# Altium schematic sheet Full Schematic.SchDoc
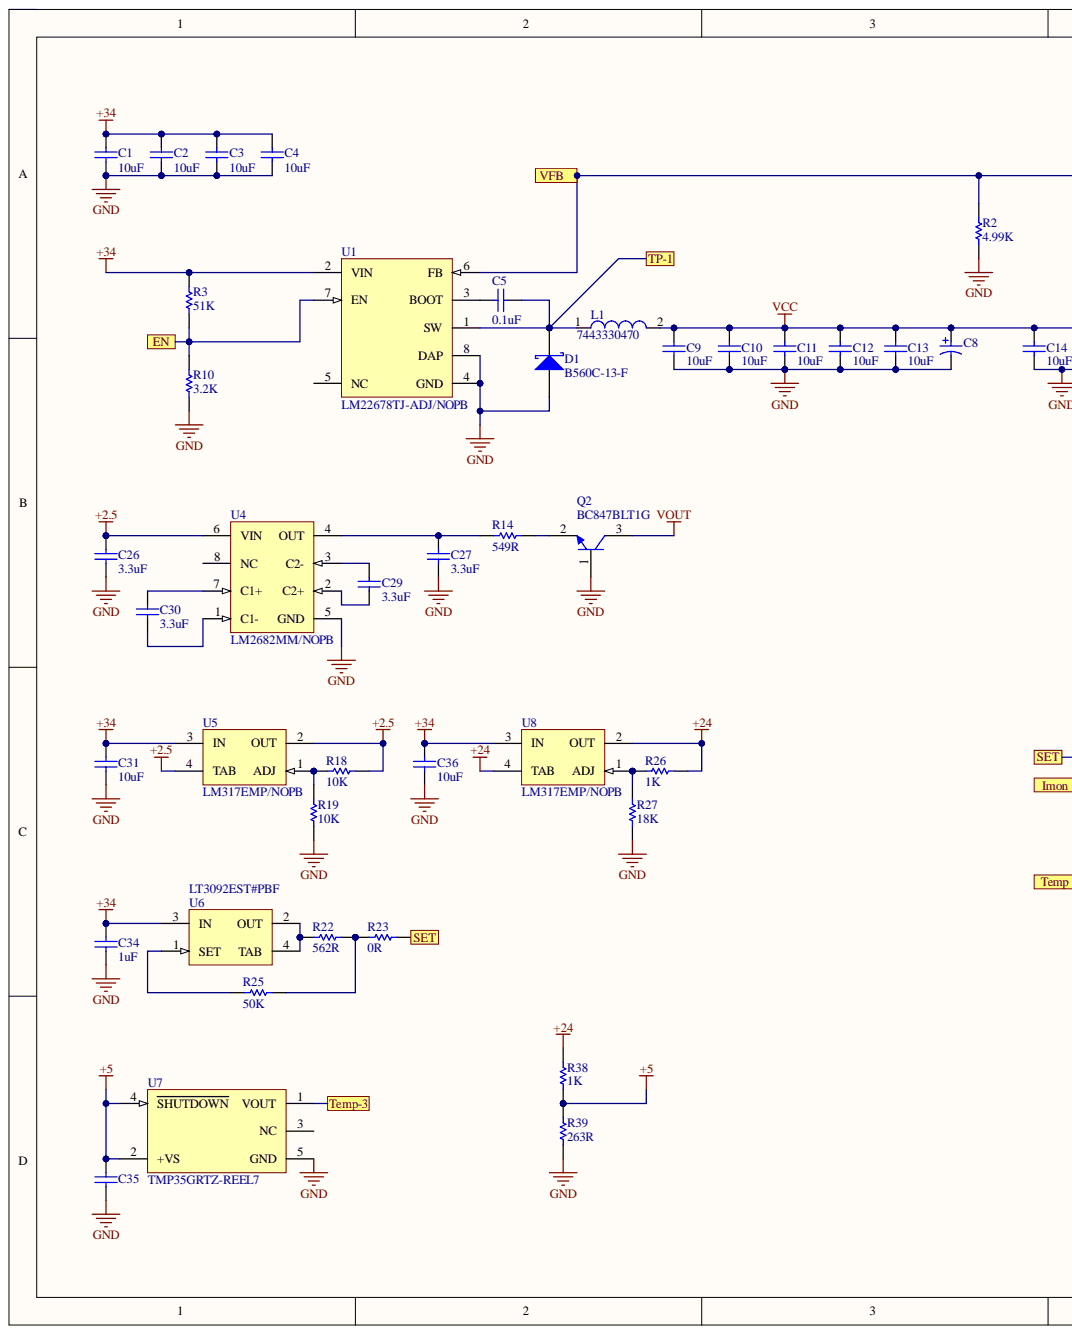
[diagram p0: Full Schematic.SchDoc, left]
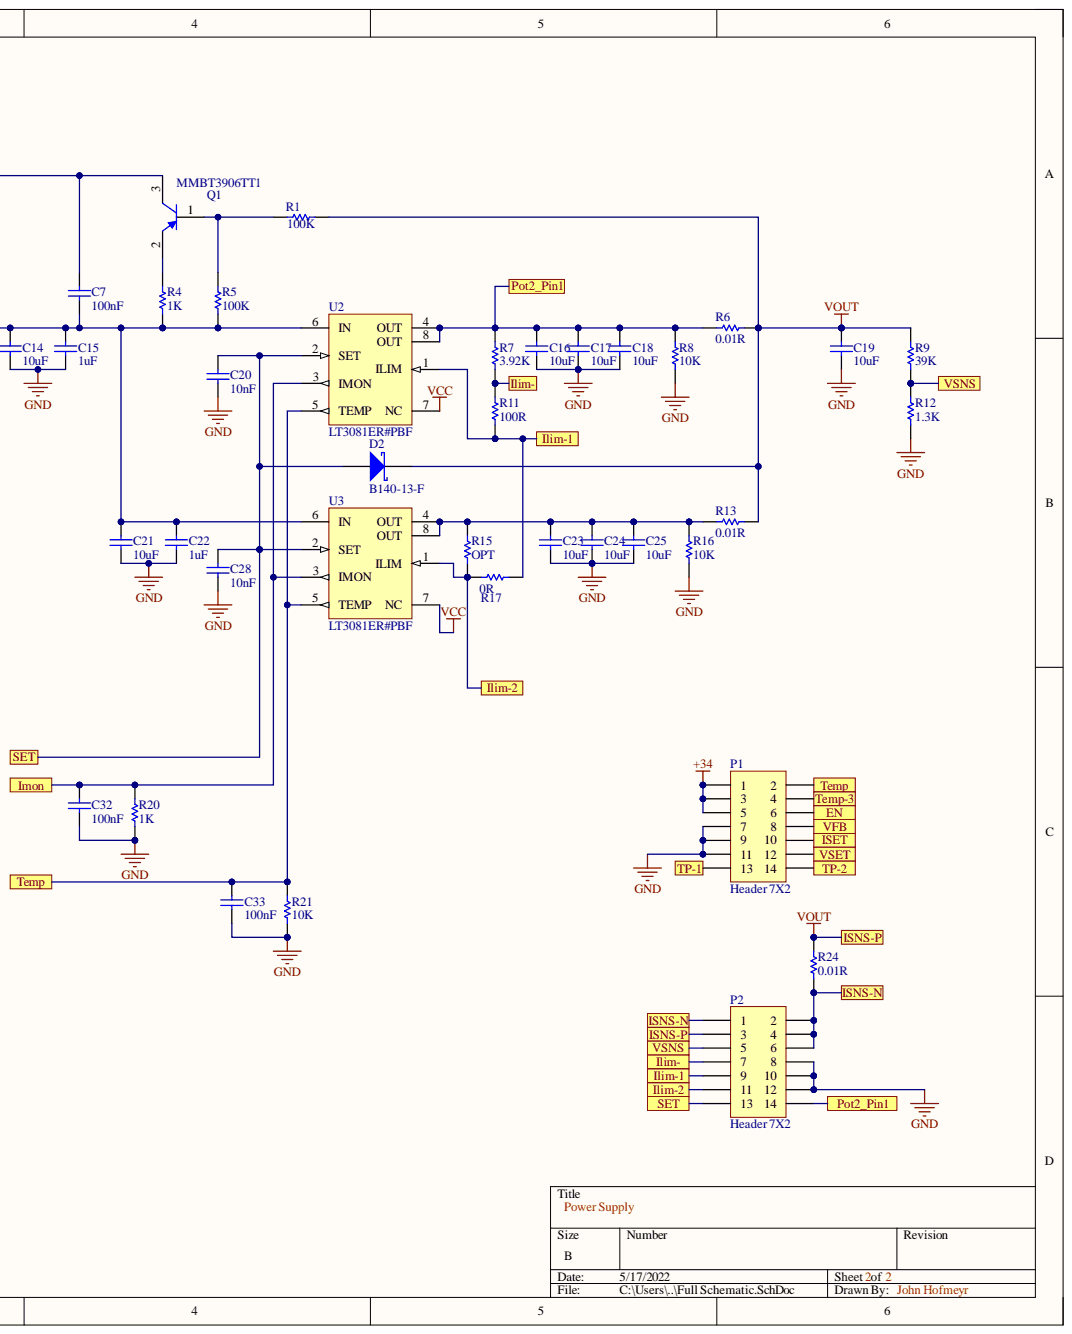
[diagram p1: Full Schematic.SchDoc, right]

## Parts
C1  10uF  [CAPC1210(3225)170_L]
C2  10uF  [CAPC1210(3225)170_L]
C3  10uF  [CAPC1210(3225)170_L]
C4  10uF  [CAPC1210(3225)170_L]
C5  0.1uF  [MURA-0805_H_1.35_M]
C7  100nF  [MURA-0805_H_1.35_M]
C8  UWT1H101MNL1GS  [NIC-UWT1H101MNL1GS_L]  (value not drawn)
C9  10uF  [CAPC1210(3225)170_L]
C10  10uF  [CAPC1210(3225)170_L]
C11  10uF  [CAPC1210(3225)170_L]
C12  10uF  [CAPC1210(3225)170_L]
C13  10uF  [CAPC1210(3225)170_L]
C14  10uF  [CAPC1210(3225)170_L]
C15  1uF  [MURA-0805_H_1.35_M]
C16  10uF  [CAPC1210(3225)170_L]
C17  10uF  [CAPC1210(3225)170_L]
C18  10uF  [CAPC1210(3225)170_L]
C19  10uF  [CAPC1210(3225)170_L]
C20  10nF  [MURA-0805_H_1.35_M]
C21  10uF  [CAPC1210(3225)170_L]
C22  1uF  [MURA-0805_H_1.35_M]
C23  10uF  [CAPC1210(3225)170_L]
C24  10uF  [CAPC1210(3225)170_L]
C25  10uF  [CAPC1210(3225)170_L]
C26  3.3uF  [MURA-0805_H_1.35_M]
C27  3.3uF  [MURA-0805_H_1.35_M]
C28  10nF  [MURA-0805_H_1.35_M]
C29  3.3uF  [MURA-0805_H_1.35_M]
C30  3.3uF  [MURA-0805_H_1.35_M]
C31  10uF  [CAPC1210(3225)170_L]
C32  100nF  [MURA-0805_H_1.35_M]
C33  100nF  [MURA-0805_H_1.35_M]
C34  1uF  [MURA-0805_H_1.35_M]
C35  (no value)  [CAPC0805(2012)130_N]
C36  10uF  [CAPC1210(3225)170_L]
D1  B560C-13-F  [DIOD-B5X0C-2_M]
D2  B140-13-F  [DIOD-B1X0-2_V]
L1  7443330470  [WE-HCC-1090]
P1  Header 7X2  [HDR2X7]
P2  Header 7X2  [HDR2X7]
Q1  MMBT3906TT1  [ONSC-SC-75-3-463-01_V]
Q2  BC847BLT1G  [ONSC-SOT-23-3-318-08_V]
R1  100K  [RESC2013X60X40LL20T25]
R2  4.99K  [RESC2013X60X40LL20T25]
R3  51K  [RESC2013X60X40LL20T25]
R4  1K  [RESC2013X60X40LL20T25]
R5  100K  [RESC2013X60X40LL20T25]
R6  0.01R  [RESC1206(3216)_M]
R7  3.92K  [RESC2013X60X40LL20T25]
R8  10K  [RESC2013X60X40LL20T25]
R9  39K  [RESC2013X60X40LL20T25]
R10  3.2K  [RESC2013X60X40LL20T25]
R11  100R  [RESC2013X60X40LL20T25]
R12  1.3K  [RESC2013X60X40LL20T25]
R13  0.01R  [RESC1206(3216)_M]
R14  549R  [RESC2013X60X40LL20T25]
R15  OPT  [RESC2013X60X40LL20T25]
R16  10K  [RESC2013X60X40LL20T25]
R17  0R  [RESC2013X60X40LL20T25]
R18  10K  [RESC2013X60X40LL20T25]
R19  10K  [RESC2013X60X40LL20T25]
R20  1K  [RESC2013X60X40LL20T25]
R21  10K  [RESC2013X60X40LL20T25]
R22  562R  [RESC2013X60X40LL20T25]
R23  0R  [RESC2013X60X40LL20T25]
R24  0.01R  [RESC1206(3216)_M]
R25  50K  [RESC2013X60X40LL20T25]
R26  1K  [RESC2013X60X40LL20T25]
R27  18K  [RESC2013X60X40LL20T25]
R38  1K  [RESC2013X60X40LL20T25]
R39  263R  [RESC2013X60X40LL20T25]
U1  LM22678TJ-ADJ/NOPB  [NDR0007A_M]
U2  LT3081ER#PBF  [LT-R-7_L]
U3  LT3081ER#PBF  [LT-R-7_L]
U4  LM2682MM/NOPB  [DGK0008A_L]
U5  LM317EMP/NOPB  [DCY0004A_L]
U6  LT3092EST#PBF  [LT-ST-3-SOT-223_M]
U7  TMP35GRTZ-REEL7  [ADI-RJ-5_N]
U8  LM317EMP/NOPB  [DCY0004A_L]

## Ports
EN, Ilim-, Ilim-1, Ilim-2, Imon, ISET, ISNS-N, ISNS-P, Pot2_Pin1, SET, Temp, Temp-3, TP-1, TP-2, VFB, VSET, VSNS

## Nets
+2.5: C26.2 R18.2 U4.6(VIN) U5.2(OUT) U5.4(TAB)
+5: C35.2 R38.2 R39.1 U7.2(+VS) U7.4(SHUTDOWN)
+24: R26.2 R38.1 U8.2(OUT) U8.4(TAB)
+34: C1.2 C2.2 C3.2 C4.2 C31.2 C34.2 C36.2 P1.1 P1.3 P1.5 R3.2 U1.2(VIN) U5.3(IN) U6.3(IN) U8.3(IN)
GND: C1.1 C2.1 C3.1 C4.1 C8.2 C9.1 C10.1 C11.1 C12.1 C13.1 C14.1 C15.1 C16.1 C17.1 C18.1 C19.1 C20.1 C21.1 C22.1 C23.1 C24.1 C25.1 C26.1 C27.1 C28.1 C31.1 C32.1 C33.1 C34.1 C35.1 C36.1 D1.2 P1.7 P1.9 P1.11 P2.8 P2.10 P2.12 Q2.1 R2.2 R8.2 R10.1 R12.2 R16.2 R19.2 R20.2 R21.2 R27.2 R39.2 U1.4(GND) U1.8(DAP) U4.5(GND) U7.5(GND)
VCC: C7.1 C8.1 C9.2 C10.2 C11.2 C12.2 C13.2 C14.2 C15.2 C21.2 C22.2 L1.2 R4.2 R5.2 U2.6(IN) U2.7(NC) U3.6(IN) U3.7(NC)
EN: P1.6 R3.1 R10.2 U1.7(EN) ; port EN
Ilim-: P2.7 R7.2 R11.1 ; port Ilim-
Ilim-1: P2.9 R11.2 R17.2 U2.1(ILIM) ; port Ilim-1
Ilim-2: P2.11 R15.1 R17.1 U3.1(ILIM) ; port Ilim-2
Imon: C32.2 R20.1 U2.3(IMON) U3.3(IMON) ; port Imon
ISET: P1.10 ; port ISET
ISNS-N: P2.1 P2.2 P2.4 P2.6 R24.1 ; port ISNS-N
Pot2_Pin1: C16.2 C17.2 C18.2 P2.14 R6.1 R7.1 R8.1 U2.4(OUT) U2.8(OUT) ; port Pot2_Pin1
SET: C20.2 C28.2 D2.2 P2.13 R23.2 U2.2(SET) U3.2(SET) ; port SET
Temp: C33.2 P1.2 R21.1 U2.5(TEMP) U3.5(TEMP) ; port Temp
Temp-3: P1.4 U7.1(VOUT) ; port Temp-3
TP-1: C5.1 D1.1 L1.1 P1.13 U1.1(SW) ; port TP-1
TP-2: P1.14 ; port TP-2
VFB: C7.2 P1.8 Q1.3 R2.1 U1.6(FB) ; port VFB
VOUT: C19.2 D2.1 P2.3 Q2.3 R1.1 R6.2 R9.1 R13.2 R24.2 ; port ISNS-P
VSET: P1.12 ; port VSET
VSNS: P2.5 R9.2 R12.1 ; port VSNS
NetC5_2: C5.2 U1.3(BOOT)
NetC23_2: C23.2 C24.2 C25.2 R13.1 R15.2 R16.1 U3.4(OUT) U3.8(OUT)
NetC27_2: C27.2 R14.1 U4.4(OUT)
NetC29_1: C29.1 U4.2(C2+)
NetC29_2: C29.2 U4.3(C2-)
NetC30_1: C30.1 U4.1(C1-)
NetC30_2: C30.2 U4.7(C1+)
NetQ1_1: Q1.1 R1.2 R5.1
NetQ1_2: Q1.2 R4.1
NetQ2_2: Q2.2 R14.2
NetR18_1: R18.1 R19.1 U5.1(ADJ)
NetR22_1: R22.1 R23.1 R25.1
NetR22_2: R22.2 U6.2(OUT) U6.4(TAB)
NetR25_2: R25.2 U6.1(SET)
NetR26_1: R26.1 R27.1 U8.1(ADJ)

Not connected: U1 5(NC); U4 8(NC); U7 3(NC)

## Library data of the parts
C1-C4 C9-C14 C16-C19 C21 C23-C25 C31 C36 = CMP-1038-00364-1: CAP 390pF 50V ±5% 1210 (3225 Metric) Thickness 1.7mm SMD; Case-EIA: 1210; Case-Metric: 3225; Tolerance: Â±5%; Component Kind: Standard; Library Name: Altium Content Vault; Max Thickness: 1.7 mm; Rated Voltage: 50 V; Technology: SMT; Value: 390pF
C5 C7 C15 C20 C22 C26-C30 C32-C34 = CMP-2000-05254-1: CAP, Ceramic, 10 uF, 10%, 10 V, 0805, RoHS, Tape and Reel; Manufacturer: Murata; Capacitance: 10µF; Catalog Drawings: GRMSeries_0805_1.25; Featured Product: GA3SafetyRecognizedCapsandHi-CapMLCC; Online Catalog: GRMSeries; Other Names: 490-1709-6; Product Training Modules: High-CapMultilayerCeramicCapacitors; Temperature Coefficient: X5R; Voltage - Rated: 10V; Applications: GeneralPurpose; Category: Capacitors; Component Kind: Standard; Datasheet URL: https://datasheet.ciiva.com/3777/210grm21br61a106ke1923-3777175.pdf; Datasheet Version: Aug-14; Family: CeramicCapacitors; Features: -; Height - Seated (Max): -; Lead Spacing: -; Lead Style: -; Library Name: Altium Content Vault; Manufacturer URL: http://www.murata.com/; Mounting Type: SurfaceMount,MLCC; Operating Temperature: -55degC~85degC; Package / Case: 0805(2012Metric); Package Description: 2-Pin Surface Mount Device (0805), Body 2.1 x 1.35 mm; Package Reference: 805; Packaging: Digi-Reel; Ratings: -; Series: GRM; Size / Dimension: 0.079"Lx0.049"W(2.00mmx1.25mm); Standard Package: 1; Thickness (Max): 0.053"(1.35mm); Tolerance: ±10%
C8 = CMP-2000-07373-1: Aluminum Electrolytic Capacitor, 100 uF, +/- 20%, 50 V, -55 to 105 degC, 2-Pin SMD, RoHS, Tape and Reel; Manufacturer: Nichicon; Capacitance: 100µF; Rated Voltage: 50V; Type: Can; Case Size/Dimension: Ø8x10mm; Component Kind: Standard; Datasheet URL: http://products.nichicon.co.jp/en/pdf/XJA043/e-uwt.pdf; Datasheet Version: 08/2015; Library Name: Altium Content Vault; Manufacturer URL: http://www.nichicon.co.jp/english/; Mounting Technology: SurfaceMount; Operating Temp-Max: 105°C; Package Description: Aluminum Electrolytic Capacitor, Body 8.3 x 8.3 mm, Height 10.8 mm; Package Reference: UWT1H101MNL1GS
C35 = CMP-1036-04061-1: CAP 22nF 50V ±5% 0805 (2012 Metric) Thickness 1.2mm SMD; Case-EIA: 0805; Case-Metric: 2012; Tolerance: Â±5%; Component Kind: Standard; Library Name: Altium Content Vault; Max Thickness: 1.2 mm; Rated Voltage: 50 V; Technology: SMT; Value: 22nF
D1 = CMP-2000-05098-2: Schottky Barrier Rectifier, 5 A, 60 V, -55 to 125 degC, 2-Pin SMD, RoHS, Tape and Reel; Brand: DiodesIncorporated; Configuration: Single; If - Forward Current: 5A; Ifsm - Forward Surge Current: 100A; Ir - Reverse Current: 500uA; Unit Weight: 0.007408oz; Vf - Forward Voltage: 0.7V; Vrrm - Repetitive Reverse Voltage: 60V; Component Kind: Standard; Datasheet URL: https://datasheet.ciiva.com/27052/1538961-27052355.pdf; Datasheet Version: Rev. 7-2, 06/2007; Factory Pack Quantity: 3000; Height: 2.5mm; Length: 7.11mm; Library Name: Altium Content Vault; Manufacturer: DiodesIncorporated; Manufacturer URL: http://www.diodes.com/; Maximum Operating Temperature: +125C; Minimum Operating Temperature: -55C; Mounting Style: SMD/SMT; Operating Temperature Range: -55Cto+125C; Package / Case: SMC; Packaging: Reel; Product: SchottkyDiodes; Product Category: SchottkyDiodes&Rectifiers; RoHS: True; Series: B560; Technology: Si; Termination Style: SMD/SMT; Type: SchottkyBarrierRectifier; Width: 6.22mm
D2 = CMP-2000-05096-1: Surface Mount Schottky Barrier Rectifier, 1 A, -65 to 150 degC, 2-Pin SMA, RoHS, Tape and Reel; Brand: DiodesIncorporated; Configuration: Single; If - Forward Current: 1A; Ifsm - Forward Surge Current: 30A; Ir - Reverse Current: 500uA; Unit Weight: 0.002258oz; Vf - Forward Voltage: 0.5V; Vrrm - Repetitive Reverse Voltage: 40V; Component Kind: Standard; Datasheet URL: http://www.diodes.com/_files/datasheets/ds13002.pdf; Datasheet Version: Rev. 18-2, 05/2013; Factory Pack Quantity: 5000; Height: 2.1mm; Length: 4.6mm; Library Name: Altium Content Vault; Manufacturer: DiodesIncorporated; Manufacturer URL: http://www.diodes.com/; Maximum Operating Temperature: +150C; Minimum Operating Temperature: -65C; Mounting Style: SMD/SMT; Operating Temperature Range: -65Cto+150C; Package / Case: SMA; Package Description: 2-Pin Surface Mount Device, Body 4.3 x 2.605 mm; Package Reference: SMA; Packaging: Reel; Product: SchottkyDiodes; Product Category: SchottkyDiodes&Rectifiers; RoHS: True; Series: B140; Technology: Si; Termination Style: SMD/SMT; Type: SchottkyBarrierRectifier; Width: 2.92mm
L1 = CMP-0226-00118-1: SMD High Current Cube Inductor WE-HCC, L=4.70 µH; Inductance: 4.70 ÂµH; Component Kind: Standard; ComponentLink1Description: Manufacturer Link; ComponentLink1URL: http://www.we-online.com; ComponentLink2Description: Datasheet; ComponentLink2URL: http://www.we-online.de/katalog/media/pdf/7443330470.pdf; DatasheetVersion: ver 2, Nov-2009; Library Name: Altium Content Vault; PackageDescription: Inductor, 2-Leads, Body 10.9 x 10.0 mm; PackageReference: WE-HCC-1090; PackageVersion: 2009
P1 P2 = Header 7X2: Header, 7-Pin, Dual row; Component Kind: Standard; LatestRevisionDate: 17-Jul-2002; LatestRevisionNote: Re-released for DXP Platform.; Library Name: Miscellaneous Connectors.IntLib; Signal Integrity: Connector; Publisher: Altium Limited
Q1 = CMP-1048-00414-1: General Purpose Transistor, PNP Silicon, 3-Pin SC-75, Tape and Reel; Manufacturer: On Semiconductor; fT Min (MHz): 250; IC Continuous (A): -0.2; Note1: 0; Component Kind: Standard; ComponentLink1Description: Manufacturer URL; ComponentLink1URL: http://www.onsemi.com/; ComponentLink2Description: Datasheet; ComponentLink2URL: http://www.onsemi.cn/pub_link/Collateral/MMBT3906TT1-D.PDF; ComponentLink3Description: Package Specification; ComponentLink3URL: http://www.onsemi.com/pub_link/Collateral/463-01.PDF; DatasheetVersion: Rev. 1; Library Name: Altium Content Vault; Mounting Technology: Surface Mount; PackageDescription: 3-Pin Small Outline Transistor, Body 1.6 x 0.8 mm, Pitch 0.5 mm; PackageReference: SC-75-3-463-01; PackageVersion: Rev. F, 07/2005; Packing: Tape and Reel; PartNumber: MMBT3906TT1; Polarity: PNP; RoHS: FALSE
Q2 = CMP-1048-00759-1: General Purpose Transistor, NPN Silicon, 3-Pin SOT-23, Pb-Free, Tape and Reel; Manufacturer: On Semiconductor; fT Min (MHz): 100; IC Continuous (A): 0.1; Component Kind: Standard; ComponentLink1Description: Manufacturer URL; ComponentLink1URL: http://www.onsemi.com/; ComponentLink2Description: Datasheet; ComponentLink2URL: http://www.onsemi.cn/pub_link/Collateral/BC846ALT1-D.PDF; ComponentLink3Description: Package Specification; ComponentLink3URL: http://www.onsemi.cn/pub_link/Collateral/318-08.PDF; DatasheetVersion: Rev. 11; Library Name: Altium Content Vault; Mounting Technology: Surface Mount; PackageDescription: 3-Pin Small Outline Transistor, Body 2.92 x 1.3 mm, Pitch 0.95 mm; PackageReference: SOT-23-3-318-08; PackageVersion: Rev. AP, 11/2009; Packing: Tape and Reel; PartNumber: BC847BLT1G; Polarity: NPN; RoHS: TRUE
R1-R5 R7-R12 R14-R23 R25-R27 R38 R39 = CMP-2001-00654-1: Manufacturer: Panasonic; Part Number: ERA6AEB563V; Component Kind: Standard; Library Name: Altium Content Vault
R6 R13 R24 = CMP-1014-00878-1: 1M87 0.25W 1% 1206 (3216 Metric)  SMD; Case-EIA: 1206; Case-Metric: 3216; Value: 1870000.00 Ohm; Component Kind: Standard; Library Name: Altium Content Vault; Power: 0.25 W; Technology: SMT; Tolerance: 1 %
U1 = CMP-0069-00297-3: 5A SIMPLE SWITCHER®, Step-Down Voltage Regulator with Precision Enable, 7-pin TO-263 Thin, Pb-Free; Manufacturer: Texas Instruments; PackageTopMarking: NSUZXYTTE#*LM22678*TJ-ADJ; PowerWise: N; Component Kind: Standard; ComponentLink1Description: Datasheet URL; ComponentLink1URL: http://www.ti.com/general/docs/lit/getliterature.tsp?genericPartNumber=LM22678&fileType=pdf; CompType: Switcher; Library Name: Altium Content Vault; PackageDescription: Thin TO-263, Molded, 9.85 x 10.16 x 2mm Body, 7 Lead, 1.27mm Pitch, Surface Mount; PackageReference: NDR0007A; PinCount: 7; RoHS: Y
U2 U3 = CMP-0476-01204-1: 1.5 A Single Resistor Rugged Linear Regulator with Monitor, 1.2 to 36 V Vin, Adjustable Vout, 7-pin DPAK (R-7), -40 to 125 degC, Pb-Free; Manufacturer: Linear Technology; Discrete Pass Element: no; Dropout Voltage(V): 1.21; Iout(mA): 1500; Isupply(mA): 1.1; Noise(uV): 27; Number of Outputs: 1; Output Voltage: Adj; PSRR(dB): 90; Vin Max(V): 36; Vin Min(V): 1.2; Vout Max(V): 34.5; Vout Min(V): 0; Component Kind: Standard; ComponentLink1Description: Manufacturer URL; ComponentLink1URL: http://www.linear.com/; ComponentLink2Description: Datasheet; ComponentLink2URL: http://cds.linear.com/docs/en/datasheet/3081f.pdf; ComponentLink3Description: Package Specification; ComponentLink3URL: http://cds.linear.com/docs/en/packaging/05081462_F_R.pdf; Library Name: Altium Content Vault; Mounting Technology: Surface Mount; PackageDescription: 7-Pin Plastic DD Pak, Body 10.2235 x 8.89 mm, Pitch 1.27 mm; PackageReference: R-7; PackageVersion: Rev. F, 05/2012; PartNumber: LT3081ER#PBF; RoHS: TRUE
U4 = CMP-0065-00105-3: Switched Capacitor Voltage Doubling Inverter, 8-pin Mini SOIC, Pb-Free; Manufacturer: Texas Instruments; CompType: Switch Caps; PackageTopMarking: ZXTT*S11A; PowerWise: N; Code_JEDEC: MO-187-AA; Component Kind: Standard; ComponentLink1Description: Datasheet URL; ComponentLink1URL: http://www.ti.com/general/docs/lit/getliterature.tsp?genericPartNumber=LM2682&fileType=pdf; Library Name: Altium Content Vault; PackageDescription: MINI SOIC, .118 x .118 x .034in, 8 Lead, .0256in Pitch; PackageReference: DGK0008A; PinCount: 8; RoHS: Y
U5 U8 = CMP-0062-01707-3: 3-Terminal Adjustable Regulator, 4-pin SOT-223, Pb-Free; Manufacturer: Texas Instruments; CompType: LDO IC; PackageTopMarking: XYTT*N01A; PowerWise: N; Code_IPC: SOT223-4; Code_JEDEC: TO-261-AA; Component Kind: Standard; ComponentLink1Description: Datasheet URL; ComponentLink1URL: http://www.ti.com/general/docs/lit/getliterature.tsp?genericPartNumber=LM317-N&fileType=pdf; Library Name: Altium Content Vault; PackageDescription: Molded SOT-223, 6.50 x 3.56 x 1.6mm Body, 4 Lead, 2.3mm Pitch; PackageReference: DCY0004A; PinCount: 4; RoHS: Y
U6 = CMP-0472-00063-1: 200 mA Two-Terminal Programmable Current Source, 0.3 mA Isupply, 3-pin SOT223 (ST-3), -40 to 125 degC, Pb-Free; Manufacturer: Linear Technology; Extended Temp: E, I, MP; Initial Accuracy(%): 1; Isupply(mA): 0.3; Output Current Range: 0.5 mA to 200 mA; Vin Max(V): 40; Vin Min(V): 1.2; Component Kind: Standard; ComponentLink1Description: Manufacturer URL; ComponentLink1URL: http://www.linear.com/; ComponentLink2Description: Datasheet; ComponentLink2URL: http://cds.linear.com/docs/en/datasheet/3092fb.pdf; ComponentLink3Description: Package Specification; ComponentLink3URL: http://cds.linear.com/docs/en/packaging/SOT_3_05-08-1630.pdf; DatasheetVersion: Rev. B; Library Name: Altium Content Vault; Mounting Technology: Surface Mount; PackageDescription: 3-Pin Plastic SOT-223, Body 3.505 x 6.505 mm, Pitch 2.3 mm; PackageReference: ST-3; PackageVersion: Jun-10; PartNumber: LT3092EST#PBF; RoHS: TRUE
U7 = CMP-0887-00046-1: Voltage Output Temperature Sensor, 2.7 to 5.5 V Supply, Industrial, 5-pin SOT23 (RJ-5), Reel; Manufacturer: Analog Devices; Code_JEDEC: MO-178-AA; Component Kind: Standard; ComponentLink1Description: Manufacturer URL; ComponentLink1URL: http://www.analog.com/en/index.html; ComponentLink2Description: Datasheet; ComponentLink2URL: http://www.analog.com/static/imported-files/data_sheets/TMP35_36_37.pdf; ComponentLink3Description: Package Specification; ComponentLink3URL: http://www.analog.com/static/imported-files/packages/PKG_PDF/SOT-23(RJ)/RJ_5.pdf; ComponentLink4Description: Product URL; ComponentLink4URL: http://www.analog.com/en/mems-sensors/digital-temperature-sensors/tmp35/products/product.html; DatasheetVersion: Rev. F; Library Name: Altium Content Vault; Mounting Technology: Surface Mount; PackageDescription: 5-Pin Small Outline Transistor Package, Body 2.9 x 1.6 mm, Pitch 0.95 mm; PackageReference: RJ-5; PackageVersion: 121608-A , 12/2008; Packing: Reel; PartNumber: TMP35GRTZ-REEL7; Supply Voltage Range: 2.7 to 5.5 V
